# Altium schematic sheet M010_LQFP48-1014.SchDoc
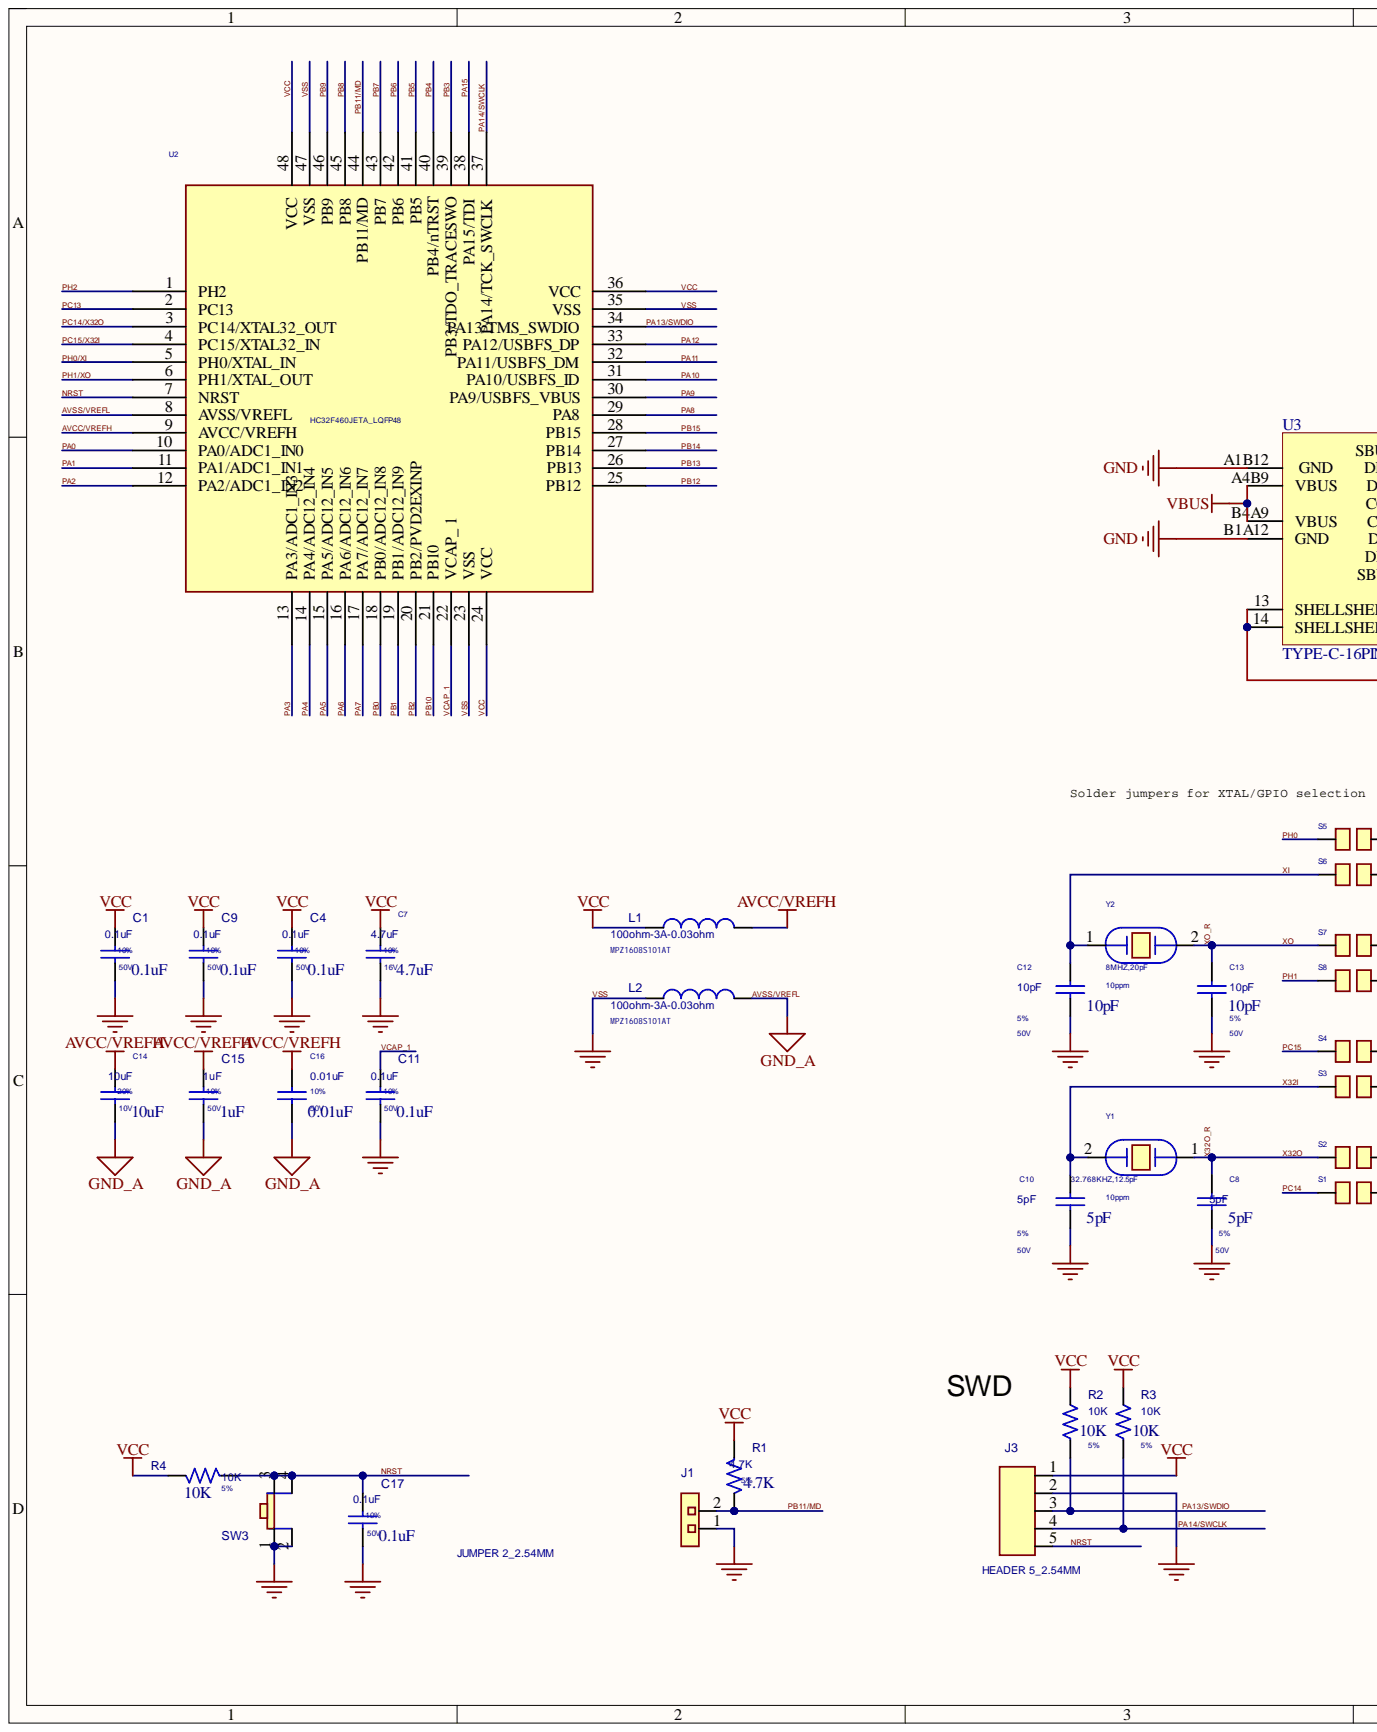
[diagram p0: M010_LQFP48-1014.SchDoc, left]
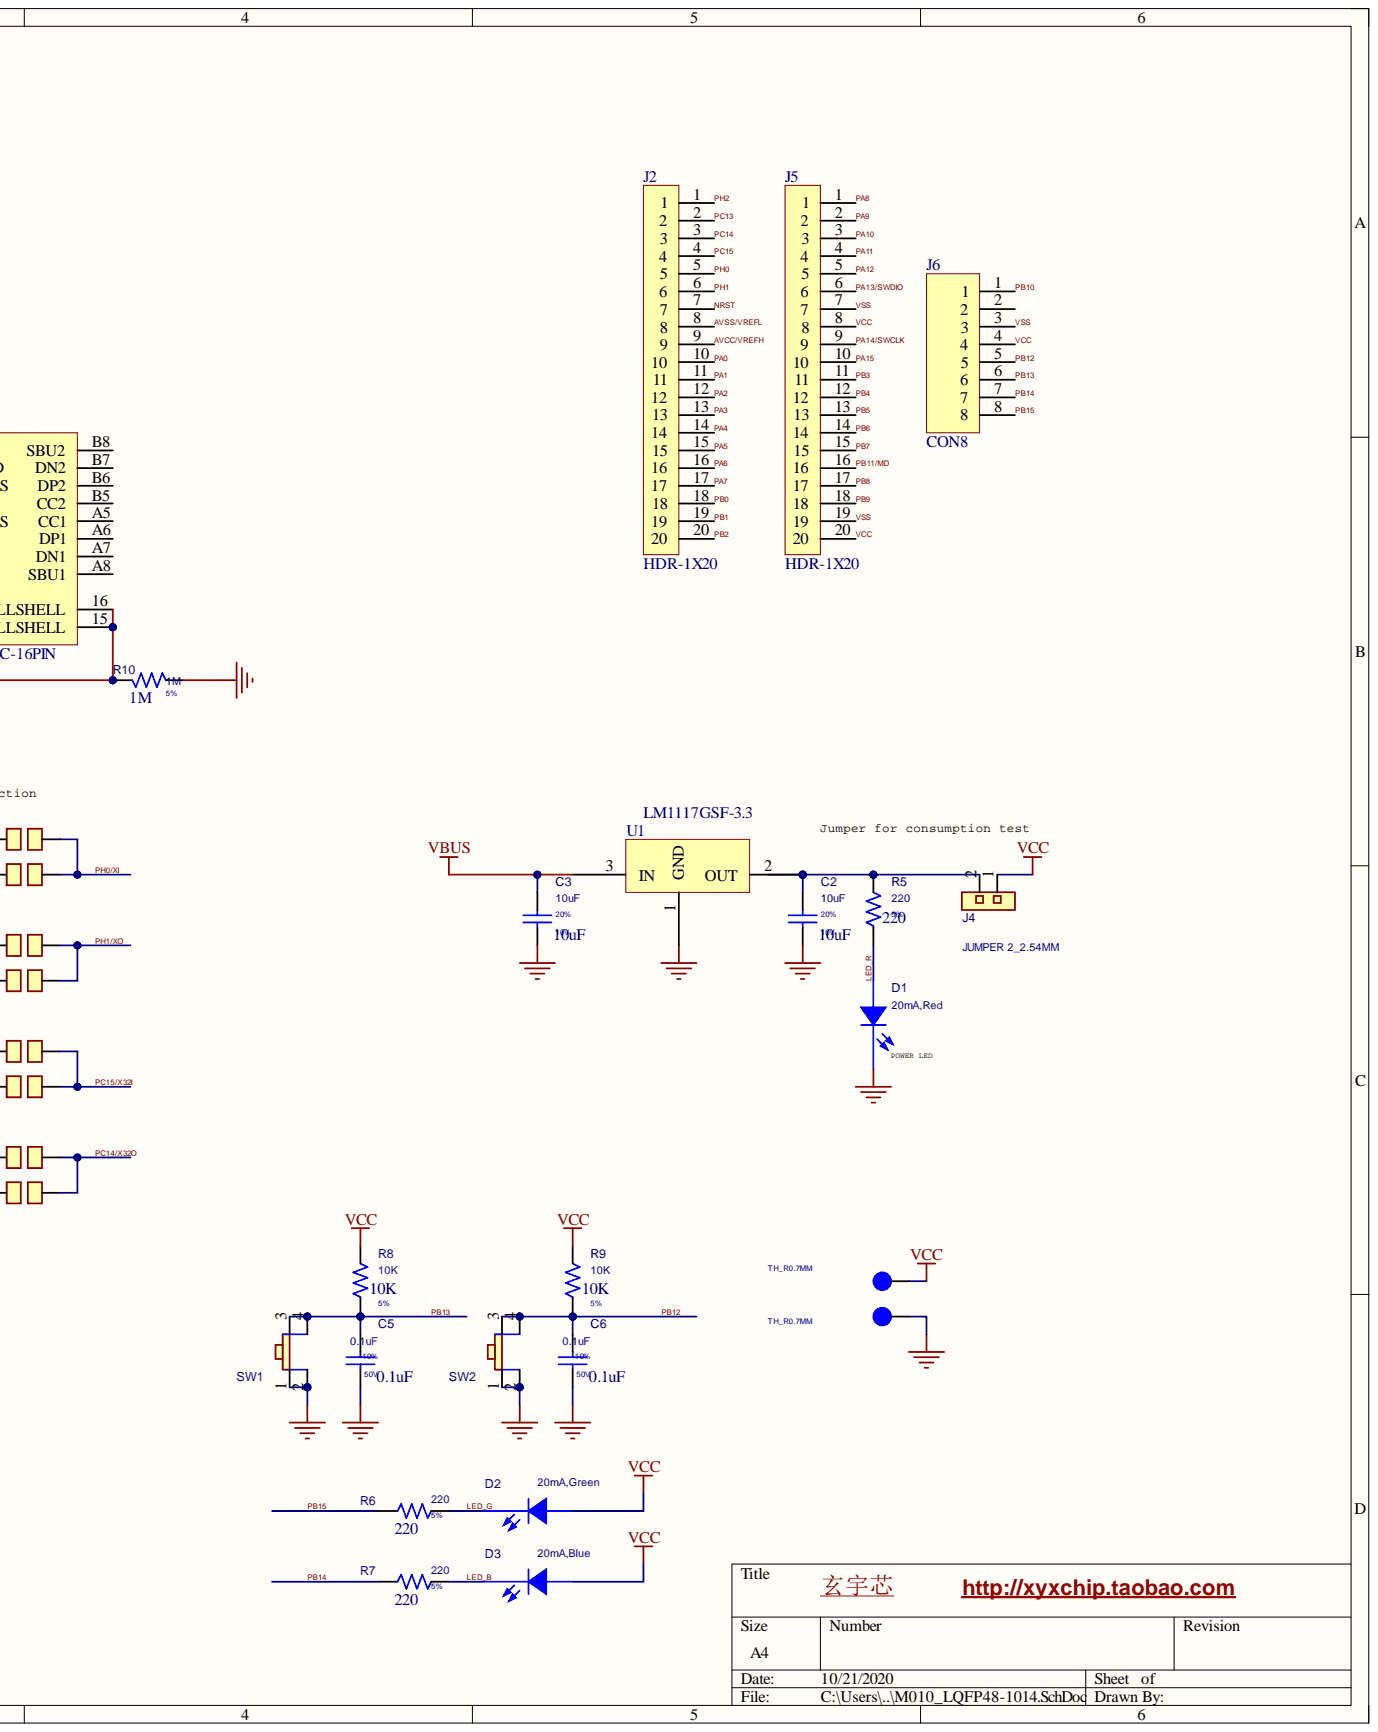
[diagram p1: M010_LQFP48-1014.SchDoc, right]

## Parts
C1  0.1uF  TOLERANCE: 10%  VOLTAGE: 50V  [0603_C]
C2  10uF  TOLERANCE: 20%  VOLTAGE: 10V  [0603_C]
C3  10uF  TOLERANCE: 20%  VOLTAGE: 10V  [0603_C]
C4  0.1uF  TOLERANCE: 10%  VOLTAGE: 50V  [0603_C]
C5  0.1uF  TOLERANCE: 10%  VOLTAGE: 50V  [0603_C]
C6  0.1uF  TOLERANCE: 10%  VOLTAGE: 50V  [0603_C]
C7  4.7uF  TOLERANCE: 10%  VOLTAGE: 16V  [0603_C]
C8  5pF  TOLERANCE: 5%  VOLTAGE: 50V  [0603_C]
C9  0.1uF  TOLERANCE: 10%  VOLTAGE: 50V  [0603_C]
C10  5pF  TOLERANCE: 5%  VOLTAGE: 50V  [0603_C]
C11  0.1uF  TOLERANCE: 10%  VOLTAGE: 50V  [0603_C]
C12  10pF  TOLERANCE: 5%  VOLTAGE: 50V  [0603_C]
C13  10pF  TOLERANCE: 5%  VOLTAGE: 50V  [0603_C]
C14  10uF  TOLERANCE: 20%  VOLTAGE: 10V  [0603_C]
C15  1uF  TOLERANCE: 10%  VOLTAGE: 50V  [0603_C]
C16  0.01uF  TOLERANCE: 10%  VOLTAGE: 50V  [0603_C]
C17  0.1uF  TOLERANCE: 10%  VOLTAGE: 50V  [0603_C]
D1  20mA,Red  [LED0805-RD]
D2  20mA,Green  [LED0805-RD]
D3  20mA,Blue  [LED0805-RD]
J1  JUMPER 2_2.54MM  [HDR-TH_2P-P2.54-V]
J2  HDR-1X20  [HDR-TH_20P-P2.54-V]
J3  HEADER 5_2.54MM  [HDR-TH_5P-P2.54-V]
J4  JUMPER 2_2.54MM  [HDR-TH_2P-P2.54-V]
J5  HDR-1X20  [HDR-TH_20P-P2.54-V]
J6  CON8  [HDR-TH_8P-P2.54-V]
L1  100ohm-3A-0.03ohm  MFG_PN01: MPZ1608S101AT  [0603_L]
L2  100ohm-3A-0.03ohm  MFG_PN01: MPZ1608S101AT  [0603_L]
R1  4.7K  TOLERANCE: 5%  [0603_R]
R2  10K  TOLERANCE: 5%  [0603_R]
R3  10K  TOLERANCE: 5%  [0603_R]
R4  10K  TOLERANCE: 5%  [0603_R]
R5  220  TOLERANCE: 5%  [0603_R]
R6  220  TOLERANCE: 5%  [0603_R]
R7  220  TOLERANCE: 5%  [0603_R]
R8  10K  TOLERANCE: 5%  [0603_R]
R9  10K  TOLERANCE: 5%  [0603_R]
R10  1M  TOLERANCE: 5%  [0603_R]
S1  SOLDER_JUMPER  [0603_R]  (value not drawn)
S2  SOLDER_JUMPER  [0603_R]  (value not drawn)
S3  SOLDER_JUMPER  [0603_R]  (value not drawn)
S4  SOLDER_JUMPER  [0603_R]  (value not drawn)
S5  SOLDER_JUMPER  [0603_R]  (value not drawn)
S6  SOLDER_JUMPER  [0603_R]  (value not drawn)
S7  SOLDER_JUMPER  [0603_R]  (value not drawn)
S8  SOLDER_JUMPER  [0603_R]  (value not drawn)
SW1  PUSHBOTTON  [KEY-SMD_4P-L6.0-W6.0-P4.5-LS9.2]  (value not drawn)
SW2  PUSHBOTTON  [KEY-SMD_4P-L6.0-W6.0-P4.5-LS9.2]  (value not drawn)
SW3  PUSHBOTTON  [KEY-SMD_4P-L6.0-W6.0-P4.5-LS9.2]  (value not drawn)
TP1  TH_R0.7MM  [HOLE1.4-2]
TP2  TH_R0.7MM  [HOLE1.4-2]
U1  LM1117GSF-3.3  [SOT-23-3]
U2  HC32F460JETA_LQFP48  [LQFP-48_L7.0-W7.0-P0.50-LS9.0-BL]
U3  TYPE-C-16PIN  [USB-C-SMD_TYPEC-304-BCP16]
Y1  32.768KHZ,12.5pF  Tolerance: 10ppm  [OSC-TH_BD3.1-P0.8-D0.3]
Y2  8MHZ,20pF  Tolerance: 10ppm  [OSC-SMD_L5.0-W3.2]

## Nets
VBUS: C3.1 U1.3 U3.A4B9(VBUS) U3.B4A9(VBUS)
AVCC/VREFH: C14.2 C15.1 C16.1 J2.9 L1.1 U2.9(AVCC/VREFH)
AVSS/VREFL: C14.1 C15.2 C16.2 J2.8 L2.1 U2.8(AVSS/VREFL)
LED_B: D3.1 R7.2
LED_G: D2.1 R6.2
LED_R: D1.2 R5.1
NRST: C17.1 J2.7 J3.5 R4.1 SW3.3 SW3.4 U2.7(NRST)
PA0: J2.10 U2.10(PA0/ADC1_IN0)
PA1: J2.11 U2.11(PA1/ADC1_IN1)
PA2: J2.12 U2.12(PA2/ADC1_IN2)
PA3: J2.13 U2.13(PA3/ADC1_IN3)
PA4: J2.14 U2.14(PA4/ADC12_IN4)
PA5: J2.15 U2.15(PA5/ADC12_IN5)
PA6: J2.16 U2.16(PA6/ADC12_IN6)
PA7: J2.17 U2.17(PA7/ADC12_IN7)
PA8: J5.1 U2.29(PA8)
PA9: J5.2 U2.30(PA9/USBFS_VBUS)
PA10: J5.3 U2.31(PA10/USBFS_ID)
PA11: J5.4 U2.32(PA11/USBFS_DM)
PA12: J5.5 U2.33(PA12/USBFS_DP)
PA13/SWDIO: J3.3 J5.6 R2.1 U2.34(PA13/TMS_SWDIO)
PA14/SWCLK: J3.4 J5.9 R3.1 U2.37(PA14/TCK_SWCLK)
PA15: J5.10 U2.38(PA15/TDI)
PB0: J2.18 U2.18(PB0/ADC12_IN8)
PB1: J2.19 U2.19(PB1/ADC12_IN9)
PB2: J2.20 U2.20(PB2/PVD2EXINP)
PB3: J5.11 U2.39(PB3/TDO_TRACESWO)
PB4: J5.12 U2.40(PB4/nTRST)
PB5: J5.13 U2.41(PB5)
PB6: J5.14 U2.42(PB6)
PB7: J5.15 U2.43(PB7)
PB8: J5.17 U2.45(PB8)
PB9: J5.18 U2.46(PB9)
PB10: J6.1 U2.21(PB10)
PB11/MD: J1.2 J5.16 R1.2 U2.44(PB11/MD)
PB12: C6.1 J6.5 R9.1 SW2.3 SW2.4 U2.25(PB12)
PB13: C5.1 J6.6 R8.1 SW1.3 SW1.4 U2.26(PB13)
PB14: J6.7 R7.1 U2.27(PB14)
PB15: J6.8 R6.1 U2.28(PB15)
PC13: J2.2 U2.2(PC13)
PC14: J2.3 S1.1
PC14/X32O: S1.2 S2.2 U2.3(PC14/XTAL32_OUT)
PC15: J2.4 S4.1
PC15/X32I: S3.2 S4.2 U2.4(PC15/XTAL32_IN)
PH0: J2.5 S5.1
PH0/XI: S5.2 S6.2 U2.5(PH0/XTAL_IN)
PH1: J2.6 S8.1
PH1/XO: S7.2 S8.2 U2.6(PH1/XTAL_OUT)
PH2: J2.1 U2.1(PH2)
VCAP_1: C11.2 U2.22(VCAP__1)
VCC: C1.1 C4.1 C7.2 C9.1 D2.2 D3.2 J3.1 J4.1 J5.8 J5.20 J6.4 L1.2 R1.1 R2.2 R3.2 R4.2 R8.2 R9.2 TP1.1 U2.24(VCC) U2.36(VCC) U2.48(VCC)
VSS: C1.2 C2.2 C3.2 C4.2 C5.2 C6.2 C7.1 C8.1 C9.2 C10.1 C11.1 C12.1 C13.1 C17.2 D1.1 J1.1 J3.2 J5.7 J5.19 J6.3 L2.2 R10.2 SW1.1 SW1.2 SW2.1 SW2.2 SW3.1 SW3.2 TP2.1 U1.1 U2.23(VSS) U2.35(VSS) U2.47(VSS) U3.A1B12(GND) U3.B1A12(GND)
X32I: C10.2 S3.1 Y1.2
X32O: C8.2 S2.1 Y1.1
XI: C12.2 S6.1 Y2.1
XO: C13.2 S7.1 Y2.2
NetC2_1: C2.1 J4.2 R5.2 U1.2
NetR10_1: R10.1 U3.13(SHELL) U3.14(SHELL) U3.15(SHELL) U3.16(SHELL)

Not connected: J6 2; U3 A5(CC1) A6(DP1) A7(DN1) A8(SBU1) B5(CC2) B6(DP2) B7(DN2) B8(SBU2)

## Library data of the parts
C1 C4-C6 C9 C11 C17 = c: CAP CER 1.0UF 10V 10% X5R 0402; MFG_NAME01: YAGEO; MFG_PN01: CC0603KRX7R9BB104; PSpiceTemplate: C^@REFDES %1 %2 ?TOLERANCE|C^@REFDES| @VALUE ?IC/IC=@IC/ ?TC/TC=@TC/ ?TOLERANCE|\n.model C^@REFDES CAP C=1 DEV=@TOLERANCE%|; SIGNAL_MODEL: DEFAULT_CAPACITOR_1e+06pF_2_1; Component Kind: Standard; HEIGHT: 0.6MM; Simulation: c; Value: 0.1uF
C2 C3 = c: CAP CER 10UF 10V 10% X5R 0603; MFG_NAME01: YAGEO; MFG_PN01: CC0603MRX5R6BB106; PSpiceTemplate: C^@REFDES %1 %2 ?TOLERANCE|C^@REFDES| @VALUE ?IC/IC=@IC/ ?TC/TC=@TC/ ?TOLERANCE|\n.model C^@REFDES CAP C=1 DEV=@TOLERANCE%|; SIGNAL_MODEL: DEFAULT_CAPACITOR_4.7e+06pF_2_1; Component Kind: Standard; HEIGHT: 1.016MM; Simulation: c; Value: 10uF; WVDC: 10V
C7 = c: CAP CER 1.0UF 10V 10% X5R 0402; AGILE_PN: 150-75772; CAD_APPROVED: Yes; FPLIST: CC0402_25,CC0402_HD,0402_CC; MFG_NAME01: MURATA; MFG_PN01: GRM188R61C475KE11; PSpiceTemplate: C^@REFDES %1 %2 ?TOLERANCE|C^@REFDES| @VALUE ?IC/IC=@IC/ ?TC/TC=@TC/ ?TOLERANCE|\n.model C^@REFDES CAP C=1 DEV=@TOLERANCE%|; SIGNAL_MODEL: DEFAULT_CAPACITOR_1e+06pF_2_1; Component Kind: Standard; HEIGHT: 0.6MM; ROHS: Yes; Simulation: c; Value: 4.7uF; WVDC: 16V
C8 C10 = c: CAP CER 30PF 50V 5% C0G 0402; AGILE_PN: 150-75266; CAD_APPROVED: Yes; FPLIST: CC0402_25,CC0402_HD,0402_CC; MFG_NAME01: MURATA; MFG_PN01: GCM1885C1H5R0CA16D; PRIORITY_PN: 021-00234; PSpiceTemplate: C^@REFDES %1 %2 ?TOLERANCE|C^@REFDES| @VALUE ?IC/IC=@IC/ ?TC/TC=@TC/ ?TOLERANCE|\n.model C^@REFDES CAP C=1 DEV=@TOLERANCE%|; SIGNAL_MODEL: DEFAULT_CAPACITOR_22pF_2_1; Component Kind: Standard; HEIGHT: 0.635MM; ROHS: Yes; Simulation: c; Value: 5pF
C12 C13 = c: CAP CER 30PF 50V 5% C0G 0402; AGILE_PN: 150-75266; CAD_APPROVED: Yes; FPLIST: CC0402_25,CC0402_HD,0402_CC; MFG_NAME01: MURATA; MFG_PN01: GRM1882C1H100JA01D; PRIORITY_PN: 021-00234; PSpiceTemplate: C^@REFDES %1 %2 ?TOLERANCE|C^@REFDES| @VALUE ?IC/IC=@IC/ ?TC/TC=@TC/ ?TOLERANCE|\n.model C^@REFDES CAP C=1 DEV=@TOLERANCE%|; SIGNAL_MODEL: DEFAULT_CAPACITOR_22pF_2_1; Component Kind: Standard; HEIGHT: 0.635MM; ROHS: Yes; Simulation: c; Value: 10pF
C14 = c: CAP CER 1.0UF 10V 10% X5R 0402; AGILE_PN: 150-75772; CAD_APPROVED: Yes; FPLIST: CC0402_25,CC0402_HD,0402_CC; MFG_NAME01: YAGEO; MFG_PN01: CC0603MRX5R6BB106; PSpiceTemplate: C^@REFDES %1 %2 ?TOLERANCE|C^@REFDES| @VALUE ?IC/IC=@IC/ ?TC/TC=@TC/ ?TOLERANCE|\n.model C^@REFDES CAP C=1 DEV=@TOLERANCE%|; SIGNAL_MODEL: DEFAULT_CAPACITOR_1e+06pF_2_1; WVDC: 16V; Component Kind: Standard; HEIGHT: 0.6MM; ROHS: Yes; Simulation: c; Value: 10uF
C15 = c: CAP CER 1.0UF 10V 10% X5R 0402; MFG_NAME01: MURATA; MFG_PN01: GRM188R61H105KAALD; PSpiceTemplate: C^@REFDES %1 %2 ?TOLERANCE|C^@REFDES| @VALUE ?IC/IC=@IC/ ?TC/TC=@TC/ ?TOLERANCE|\n.model C^@REFDES CAP C=1 DEV=@TOLERANCE%|; SIGNAL_MODEL: DEFAULT_CAPACITOR_1e+06pF_2_1; Component Kind: Standard; HEIGHT: 0.6MM; Simulation: c; Value: 1uF
C16 = c: CAP CER 2.2UF 10V 10% X5R 0603; AGILE_PN: 150-75487; CAD_APPROVED: Yes; MFG_NAME01: MURATA; MFG_PN01: GRM188R71H103KA01D; PSpiceTemplate: C^@REFDES %1 %2 ?TOLERANCE|C^@REFDES| @VALUE ?IC/IC=@IC/ ?TC/TC=@TC/ ?TOLERANCE|\n.model C^@REFDES CAP C=1 DEV=@TOLERANCE%|; SIGNAL_MODEL: DEFAULT_CAPACITOR_4.7e+06pF_2_1; Component Kind: Standard; HEIGHT: 1.016MM; ROHS: Yes; Simulation: c; Value: 0.01uF
D1 = LED_LTST_C150GKT: CLASS: DISCRETE; MFG_NAME01: EVERLIGHT; MFG_PN01: 19-217/R6C-AL1M2VY/3T; TN: 257-0020-000; Component Kind: Standard
D2 = LED_LTST_C150GKT: CLASS: DISCRETE; MFG_NAME01: EVERLIGHT; MFG_PN01: 19-217/GHC-YR1S2/3T; TN: 257-0020-000; Component Kind: Standard
D3 = LED_LTST_C150GKT: CLASS: DISCRETE; MFG_NAME01: EVERLIGHT; MFG_PN01: 19-217/BHC-ZL1M2RY/3T; TN: 257-0020-000; Component Kind: Standard
J1 J4 = 2H_Header_2x1: MfrNum: PH1-230/120-021; PartName: HEADER2Pin; TIIntPartnumber: 2H0000; Cost10K: 0.252; Cost100: 0.016; DescriptiveText: Single Line Header 2pin Tin 0.1in pitch; MFG_NAME01: OnShoreTechnology; MFG_PN01: 2.54mm,1*2Pin,直插排针; Vendor: Digikey; Component Kind: Standard; Mfr: OnShoreTechnology
J2 J5 = CON20: Component Kind: Standard; Library Name: HPD.SCHLIB
J3 = 2H_Header_5x1: Mfr: Sullins; MfrNum: PEC05SAAN; PartName: HEADER5Pin; TIIntPartnumber: 2H0018; Cost10K: 0.294; Cost100: 0.321; DescriptiveText: Single Line Header 5pin Tin 0.1in pitch; MFG_NAME01: xxx; MFG_PN01: 2.54mm,1*5Pin,直插排针; Vendor: Digikey; Component Kind: Standard
L1 L2 = INDUCTOR_L: MFG_NAME01: TDK; Component Kind: Standard
R1 = r: RES MF 10.0K 1/16W 1% 0402; MFG_NAME01: YAGEO; MFG_PN01: RC0603JR-074K7L; Power: 1/10W; PSpiceTemplate: R^@REFDES %1 %2 ?TOLERANCE|R^@REFDES| @VALUE  ?TOLERANCE|\n.model r^@REFDES RES R=1 DEV=@TOLERANCE%|; SIGNAL_MODEL: DEFAULT_RESISTOR_10000OHM_2_1; Component Kind: Standard; Simulation: r; Value: 4.7K
R2 R3 R8 R9 = r: MFG_NAME01: YAGEO; MFG_PN01: RC0603JR-0710KL; Power: 1/10W; PSpiceTemplate: R^@REFDES %1 %2 ?TOLERANCE|R^@REFDES| @VALUE  ?TOLERANCE|\n.model r^@REFDES RES R=1 DEV=@TOLERANCE%|; Component Kind: Standard; Simulation: r; Value: 10K
R4 = r: RES MF 100K 1/16W 5% 0402; MFG_NAME01: YAGEO; MFG_PN01: RC0603JR-0710KL; Power: 1/10W; PSpiceTemplate: R^@REFDES %1 %2 ?TOLERANCE|R^@REFDES| @VALUE  ?TOLERANCE|\n.model r^@REFDES RES R=1 DEV=@TOLERANCE%|; SIGNAL_MODEL: DEFAULT_RESISTOR_10000OHM_2_1; Component Kind: Standard; Simulation: r; Value: 10K
R5-R7 = r: RES MF 220 1/16W 1% 0402; AGILE_PN: 470-75416; CAD_APPROVED: Yes; FPLIST: RC0402_25,RC0402_HD,0402_CC; MFG_NAME01: YAGEO; MFG_PN01: RC0603JR-07220RL; Power: 1/10W; PSpiceTemplate: R^@REFDES %1 %2 ?TOLERANCE|R^@REFDES| @VALUE  ?TOLERANCE|\n.model r^@REFDES RES R=1 DEV=@TOLERANCE%|; SIGNAL_MODEL: DEFAULT_RESISTOR_10000OHM_2_1; Component Kind: Standard; ROHS: Yes; Simulation: r; Value: 220
R10 = r: RES MF 10.0K 1/16W 1% 0402; MFG_NAME01: YAGEO; MFG_PN01: RC0603JR-071ML; Power: 1/10W; PSpiceTemplate: R^@REFDES %1 %2 ?TOLERANCE|R^@REFDES| @VALUE  ?TOLERANCE|\n.model r^@REFDES RES R=1 DEV=@TOLERANCE%|; SIGNAL_MODEL: DEFAULT_RESISTOR_10000OHM_2_1; Component Kind: Standard; Simulation: r; Value: 1M
S1-S8 = solder_jumper: Silkscreen: <Silkscreen>; Voltage: <Voltage>; Component Kind: Standard; Package: <Package>
SW1-SW3 = 2W_Switch_Buttonsmd: Mfr: Omron; MfrNum: B3SL-1022P; PartName: SwitchPushMomTactilesmd; TIIntPartnumber: 2W0018; Cost10K: 0.331; Cost100: 0.340; DescriptiveText: Switch Push Button tactile; MFG_NAME01: ALPS; MFG_PN01: SKRPABE010; Vendor: Digikey; Component Kind: Standard
TP1 TP2 = 2H_TestPointThroughHoleR28: Mfr: Keystone Electronics; MfrNum: 5002; TIIntPartnumber: 2H0026; Cost10K: 0.10; Cost100: 0.163; DescriptiveText: Testpoint Miniature Through Hole; Vendor: Digikey; Component Kind: Standard; PartName: TestPointThroughHole
U1 = 7812: Component Kind: Standard; Library Name: YZJ-SCH库-0328.SchLib
U2 = HC32F460JETA: Component Kind: Standard
Y1 = XTAL: XTAL 32.768KHZ 12.5PF 20PPM 3.2X1.5MM SMT; AGILE_PN: 230-77653; CAD_APPROVED: Yes; CLASS: IC; MFG_NAME01: YXC; MFG_PN01: X206032768KGB2SC; Component Kind: Standard; HEIGHT: 0.9MM; ROHS: Yes
Y2 = XTAL: XTAL 32.768KHZ 12.5PF 20PPM 3.2X1.5MM SMT; AGILE_PN: 230-77653; CAD_APPROVED: Yes; CLASS: IC; MFG_NAME01: YXC; MFG_PN01: X49SD8MSB2SC; Component Kind: Standard; HEIGHT: 0.9MM; ROHS: Yes
J6 = CON8: Component Kind: Standard; Library Name: HPD.SCHLIB
U3 = TYPE-C-16PIN: Component Kind: Standard; Library Name: Schlib1.SchLib
